# Altium schematic sheet ZYNQ_DDR_BANK.SchDoc
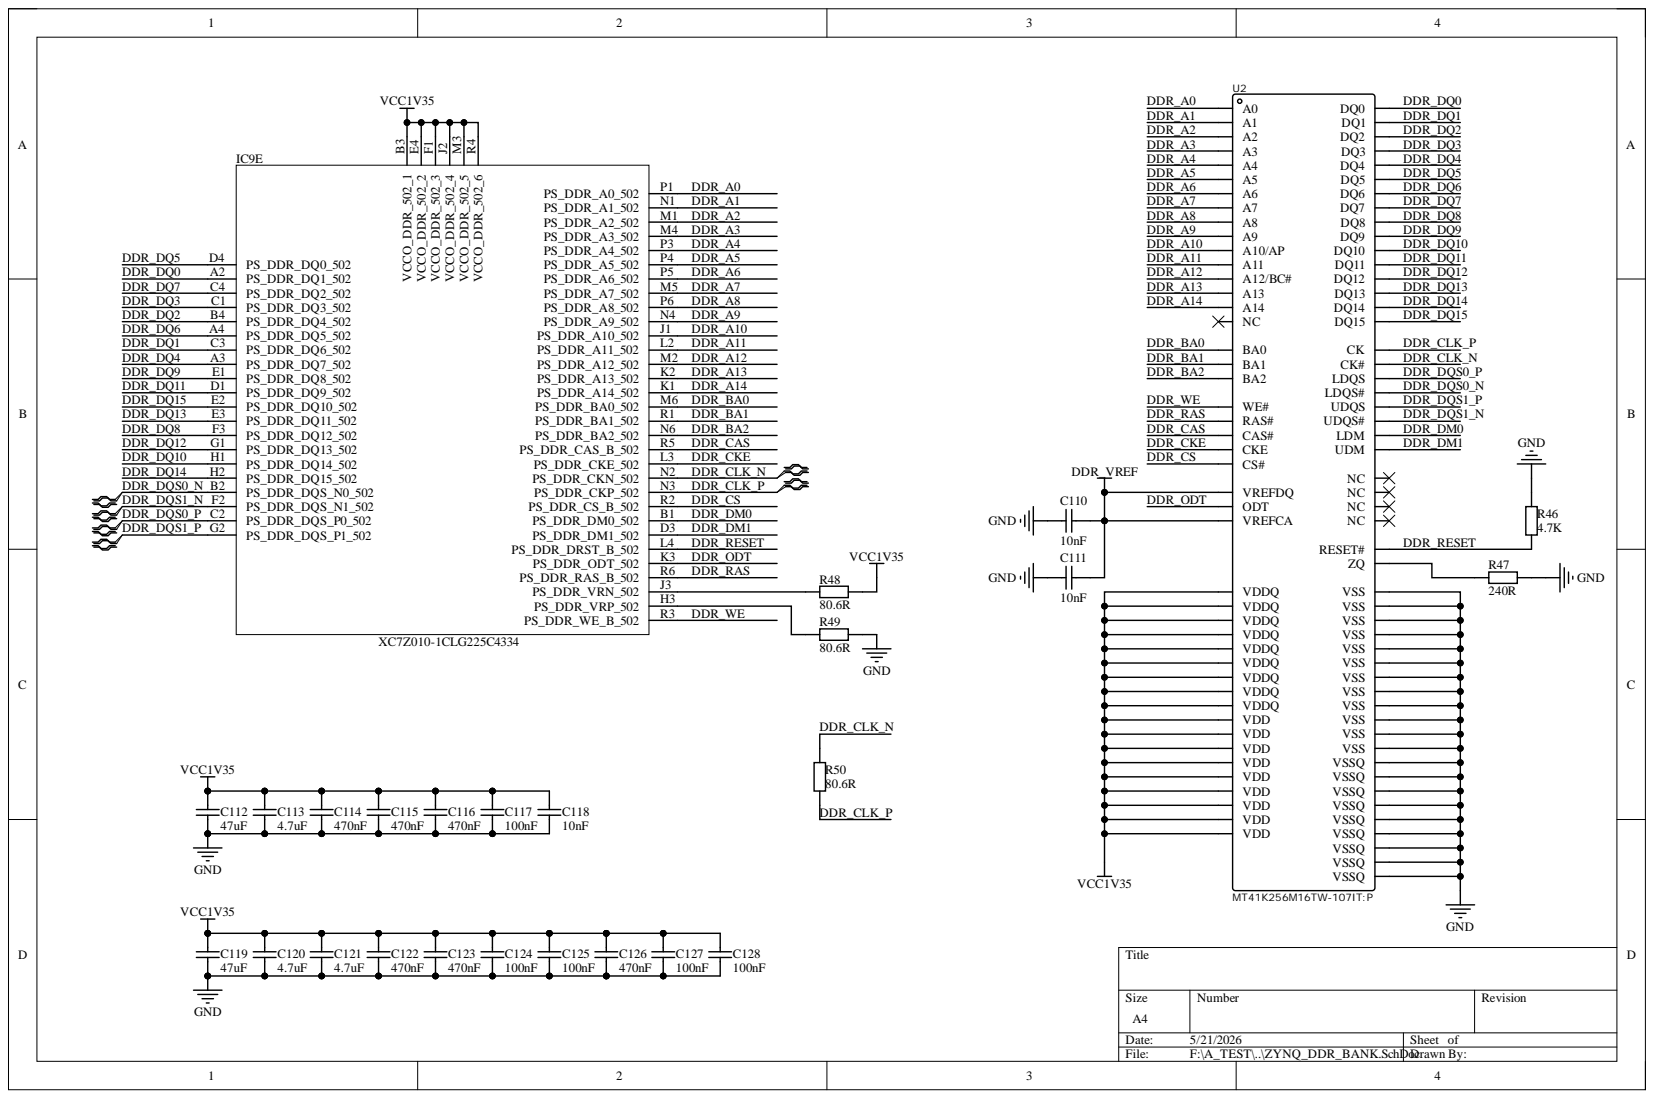
[diagram p0: ZYNQ_DDR_BANK.SchDoc]

## Parts
C110  10nF  [C 0402_L]
C111  10nF  [C 0402_L]
C112  47uF  [C 0805_L]
C113  4.7uF  [C 0603_L]
C114  470nF  [C 0402_L]
C115  470nF  [C 0402_L]
C116  470nF  [C 0402_L]
C117  100nF  [C 0402_L]
C118  10nF  [C 0402_L]
C119  47uF  [C 0805_L]
C120  4.7uF  [C 0603_L]
C121  4.7uF  [C 0603_L]
C122  470nF  [C 0402_L]
C123  470nF  [C 0402_L]
C124  100nF  [C 0402_L]
C125  100nF  [C 0402_L]
C126  470nF  [C 0402_L]
C127  100nF  [C 0402_L]
C128  100nF  [C 0402_L]
IC9  XC7Z010-1CLG225C4334  [BGA225C80P15X15_1300X1300X150]  (part E)
R46  4.7K  [R 0402_L]
R47  240R  [R 0402_L]
R48  80.6R  [R 0402_L]
R49  80.6R  [R 0402_L]
R50  80.6R  [R 0402_L]
U2  MT41K256M16TW-107IT:P  [MICT-JT-96]

## Nets
DDR_VREF: C110.2 C111.2 U2.H1(VREFDQ) U2.M8(VREFCA)
GND: C110.1 C111.1 C112.1 C113.1 C114.1 C115.1 C116.1 C117.1 C118.1 C119.1 C120.1 C121.1 C122.1 C123.1 C124.1 C125.1 C126.1 C127.1 C128.1 R46.2 R47.2 R49.2 U2.A9(VSS) U2.B1(VSSQ) U2.B3(VSS) U2.B9(VSSQ) U2.D1(VSSQ) U2.D8(VSSQ) U2.E1(VSS) U2.E2(VSSQ) U2.E8(VSSQ) U2.F9(VSSQ) U2.G1(VSSQ) U2.G8(VSS) U2.G9(VSSQ) U2.J2(VSS) U2.J8(VSS) U2.M1(VSS) U2.M9(VSS) U2.P1(VSS) U2.P9(VSS) U2.T1(VSS) U2.T9(VSS)
VCC1V35: C112.2 C113.2 C114.2 C115.2 C116.2 C117.2 C118.2 C119.2 C120.2 C121.2 C122.2 C123.2 C124.2 C125.2 C126.2 C127.2 C128.2 IC9.B3(VCCO_DDR_502_1) IC9.E4(VCCO_DDR_502_2) IC9.F1(VCCO_DDR_502_3) IC9.J2(VCCO_DDR_502_4) IC9.M3(VCCO_DDR_502_5) IC9.R4(VCCO_DDR_502_6) R48.2 U2.A1(VDDQ) U2.A8(VDDQ) U2.B2(VDD) U2.C1(VDDQ) U2.C9(VDDQ) U2.D2(VDDQ) U2.D9(VDD) U2.E9(VDDQ) U2.F1(VDDQ) U2.G7(VDD) U2.H2(VDDQ) U2.H9(VDDQ) U2.K2(VDD) U2.K8(VDD) U2.N1(VDD) U2.N9(VDD) U2.R1(VDD) U2.R9(VDD)
DDR_A0: IC9.P1(PS_DDR_A0_502) U2.N3(A0)
DDR_A1: IC9.N1(PS_DDR_A1_502) U2.P7(A1)
DDR_A2: IC9.M1(PS_DDR_A2_502) U2.P3(A2)
DDR_A3: IC9.M4(PS_DDR_A3_502) U2.N2(A3)
DDR_A4: IC9.P3(PS_DDR_A4_502) U2.P8(A4)
DDR_A5: IC9.P4(PS_DDR_A5_502) U2.P2(A5)
DDR_A6: IC9.P5(PS_DDR_A6_502) U2.R8(A6)
DDR_A7: IC9.M5(PS_DDR_A7_502) U2.R2(A7)
DDR_A8: IC9.P6(PS_DDR_A8_502) U2.T8(A8)
DDR_A9: IC9.N4(PS_DDR_A9_502) U2.R3(A9)
DDR_A10: IC9.J1(PS_DDR_A10_502) U2.L7(A10/AP)
DDR_A11: IC9.L2(PS_DDR_A11_502) U2.R7(A11)
DDR_A12: IC9.M2(PS_DDR_A12_502) U2.N7(A12/BC#)
DDR_A13: IC9.K2(PS_DDR_A13_502) U2.T3(A13)
DDR_A14: IC9.K1(PS_DDR_A14_502) U2.T7(A14)
DDR_BA0: IC9.M6(PS_DDR_BA0_502) U2.M2(BA0)
DDR_BA1: IC9.R1(PS_DDR_BA1_502) U2.N8(BA1)
DDR_BA2: IC9.N6(PS_DDR_BA2_502) U2.M3(BA2)
DDR_CAS: IC9.R5(PS_DDR_CAS_B_502) U2.K3(CAS#)
DDR_CKE: IC9.L3(PS_DDR_CKE_502) U2.K9(CKE)
DDR_CLK_N: IC9.N2(PS_DDR_CKN_502) R50.2 U2.K7(CK#)
DDR_CLK_P: IC9.N3(PS_DDR_CKP_502) R50.1 U2.J7(CK)
DDR_CS: IC9.R2(PS_DDR_CS_B_502) U2.L2(CS#)
DDR_DM0: IC9.B1(PS_DDR_DM0_502) U2.E7(LDM)
DDR_DM1: IC9.D3(PS_DDR_DM1_502) U2.D3(UDM)
DDR_DQ0: IC9.A2(PS_DDR_DQ1_502) U2.E3(DQ0)
DDR_DQ1: IC9.C3(PS_DDR_DQ6_502) U2.F7(DQ1)
DDR_DQ2: IC9.B4(PS_DDR_DQ4_502) U2.F2(DQ2)
DDR_DQ3: IC9.C1(PS_DDR_DQ3_502) U2.F8(DQ3)
DDR_DQ4: IC9.A3(PS_DDR_DQ7_502) U2.H3(DQ4)
DDR_DQ5: IC9.D4(PS_DDR_DQ0_502) U2.H8(DQ5)
DDR_DQ6: IC9.A4(PS_DDR_DQ5_502) U2.G2(DQ6)
DDR_DQ7: IC9.C4(PS_DDR_DQ2_502) U2.H7(DQ7)
DDR_DQ8: IC9.F3(PS_DDR_DQ12_502) U2.D7(DQ8)
DDR_DQ9: IC9.E1(PS_DDR_DQ8_502) U2.C3(DQ9)
DDR_DQ10: IC9.H1(PS_DDR_DQ14_502) U2.C8(DQ10)
DDR_DQ11: IC9.D1(PS_DDR_DQ9_502) U2.C2(DQ11)
DDR_DQ12: IC9.G1(PS_DDR_DQ13_502) U2.A7(DQ12)
DDR_DQ13: IC9.E3(PS_DDR_DQ11_502) U2.A2(DQ13)
DDR_DQ14: IC9.H2(PS_DDR_DQ15_502) U2.B8(DQ14)
DDR_DQ15: IC9.E2(PS_DDR_DQ10_502) U2.A3(DQ15)
DDR_DQS0_N: IC9.B2(PS_DDR_DQS_N0_502) U2.G3(LDQS#)
DDR_DQS0_P: IC9.C2(PS_DDR_DQS_P0_502) U2.F3(LDQS)
DDR_DQS1_N: IC9.F2(PS_DDR_DQS_N1_502) U2.B7(UDQS#)
DDR_DQS1_P: IC9.G2(PS_DDR_DQS_P1_502) U2.C7(UDQS)
DDR_ODT: IC9.K3(PS_DDR_ODT_502) U2.K1(ODT)
DDR_RAS: IC9.R6(PS_DDR_RAS_B_502) U2.J3(RAS#)
DDR_RESET: IC9.L4(PS_DDR_DRST_B_502) R46.1 U2.T2(RESET#)
DDR_WE: IC9.R3(PS_DDR_WE_B_502) U2.L3(WE#)
NetIC9_H3: IC9.H3(PS_DDR_VRP_502) R49.1
NetIC9_J3: IC9.J3(PS_DDR_VRN_502) R48.1
NetR47_1: R47.1 U2.L8(ZQ)

Not connected: U2 J1(NC) J9(NC) L1(NC) L9(NC) M7(NC)

## Library data of the parts
C110-C128 = Cap: 贴片电容; Component Kind: Standard; Library Name: A_Schlib.SchLib
  C110 C111 C118: value: 10nF
  C112 C119: value: 47uF
  C113 C120 C121: value: 4.7uF
  C114-C116 C122 C123 C126: value: 470nF
  C117 C124 C125 C127 C128: value: 100nF
IC9 = XC7Z010-1CLG225C4334: Integrated Circuit; Manufacturer_Name: AMD; Component Kind: Standard; Datasheet Link: https://docs.amd.com/api/khub/documents/kOAtMqkVamwUDaIfCXO91A/content?Ft-Calling-App=ft%2Fturnkey-portal&Ft-Calling-App-Version=5.2.5#; Height: 1.5mm; Library Name: SamacSys.SchLib; Manufacturer_Part_Number: XC7Z010-1CLG225C4334
R46-R50 = Res: 通用; Component Kind: Standard; Library Name: A_Schlib.SchLib
  R46: value: 4.7K
  R47: value: 240R
  R48-R50: value: 80.6R
U2 = MT41K256M16TW-107IT:P: Component Kind: Standard; Library Name: A_Schlib.SchLib
